# Altium schematic sheet WemosESP8266.SchDoc(WemosESP8266)
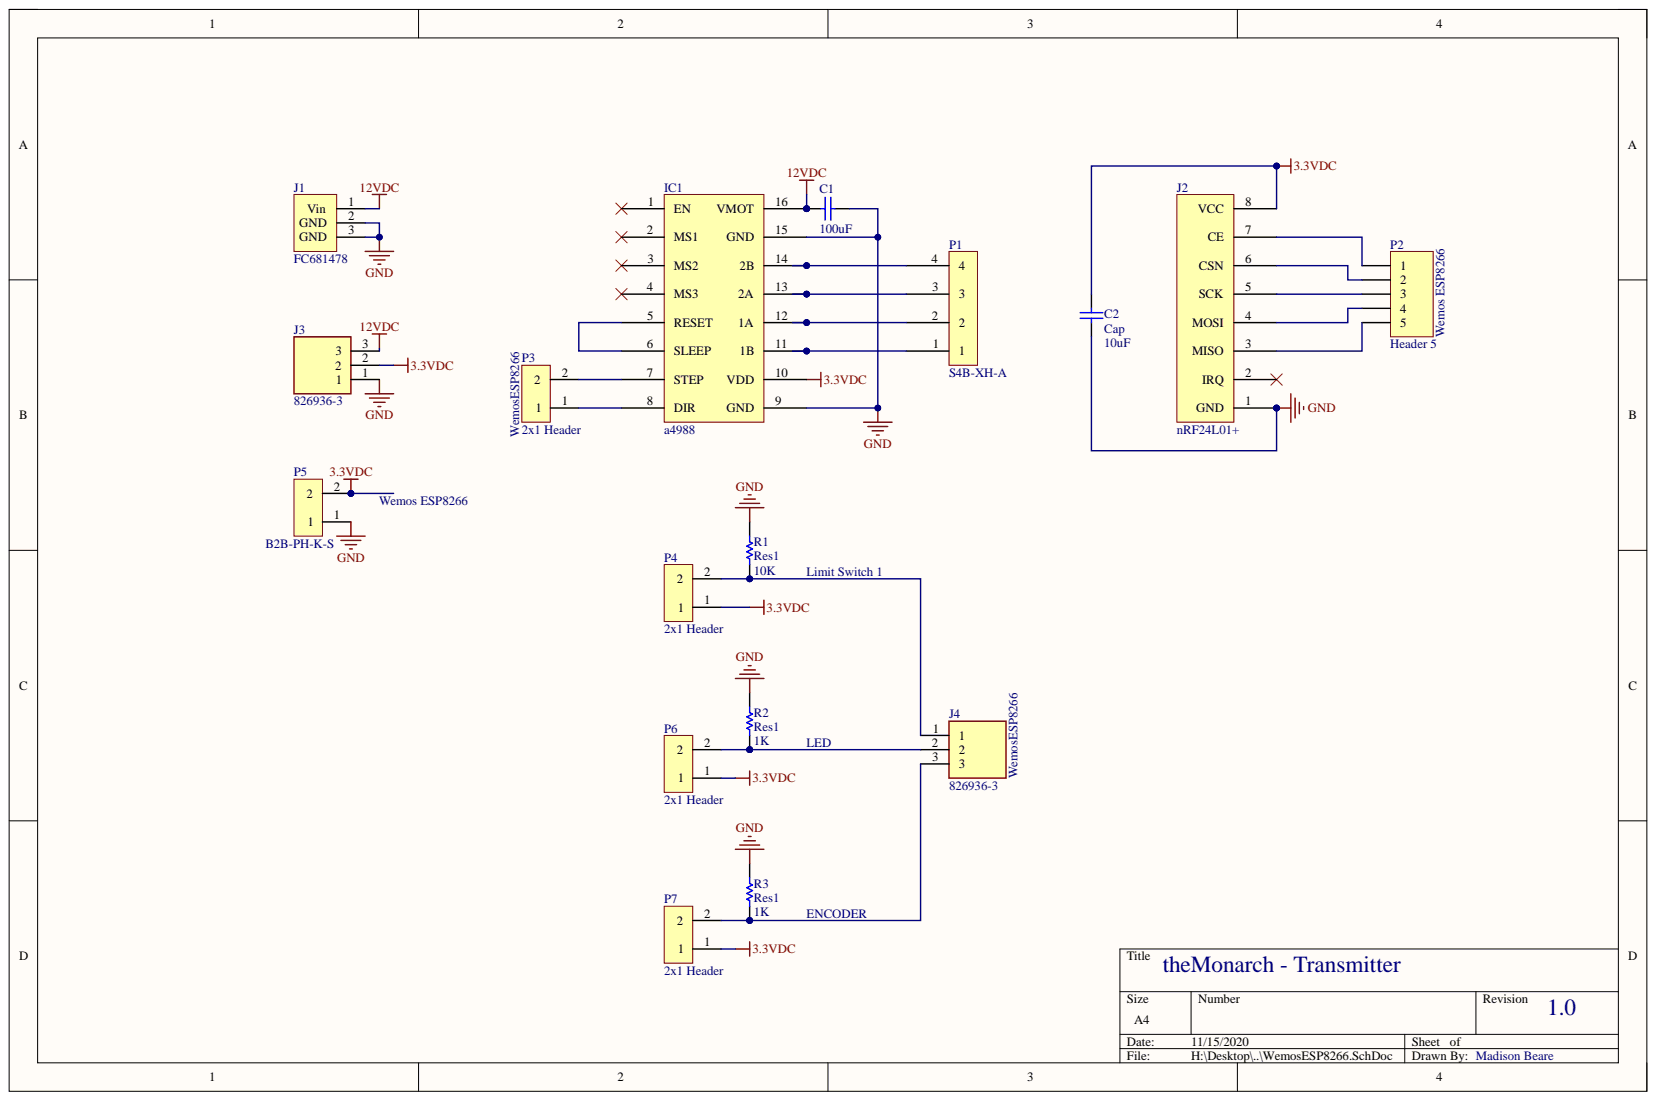
[diagram p0: WemosESP8266.SchDoc]

## Parts
C1  100uF  [RAD-0.3]
C2  Cap  value 10uF  [RAD-0.3]
IC1  a4988  [MODULE_A4988_STEPPER_MOTOR_DRIVER_CARRIER]
J1  FC681478  [FC681478]
J2  nRF24L01+  [TE_5-146278-8]
J3  826936-3  [TE_826936-3]
J4  826936-3  [TE_826936-3]
P1  S4B-XH-A  [S4B-XH-A(LF)(SN)]
P2  Header 5  [HDR1X5]
P3  2x1 Header  [JST_S2B-XH-A(LF)(SN)]
P4  2x1 Header  [JST_S2B-XH-A(LF)(SN)]
P5  B2B-PH-K-S  [JST_B2B-PH-K-S(LF)(SN)]
P6  2x1 Header  [JST_S2B-XH-A(LF)(SN)]
P7  2x1 Header  [JST_S2B-XH-A(LF)(SN)]
R1  Res1  value 10K  [AXIAL-0.3]
R2  Res1  value 1K  [AXIAL-0.3]
R3  Res1  value 1K  [AXIAL-0.3]

## Nets
3.3VDC: C2.2 IC1.10(VDD) J2.8(VCC) J3.2 P4.1 P5.2 P6.1 P7.1
12VDC: C1.1 IC1.16(VMOT) J1.1 J3.3
GND: C1.2 C2.1 IC1.9(GND) IC1.15(GND) J1.2 J1.3 J2.1(GND) J3.1 P5.1 R1.2 R2.2 R3.2
NetIC1_5: IC1.5(RESET) IC1.6(SLEEP)
NetIC1_7: IC1.7(STEP) P3.2
NetIC1_8: IC1.8(DIR) P3.1
NetIC1_11: IC1.11(1B) P1.1
NetIC1_12: IC1.12(1A) P1.2
NetIC1_13: IC1.13(2A) P1.3
NetIC1_14: IC1.14(2B) P1.4
NetJ2_3: J2.3(MISO) P2.5
NetJ2_4: J2.4(MOSI) P2.4
NetJ2_5: J2.5(SCK) P2.3
NetJ2_6: J2.6(CSN) P2.2
NetJ2_7: J2.7(CE) P2.1
NetJ4_1: J4.1 P4.2 R1.1
NetJ4_2: J4.2 P6.2 R2.1
NetJ4_3: J4.3 P7.2 R3.1

Not connected: IC1 1(EN) 2(MS1) 3(MS2) 4(MS3); J2 2(IRQ)

## Library data of the parts
C1 = Cap: Capacitor; Value: 100pF; Component Kind: Standard; LatestRevisionDate: 17-Jul-2002; LatestRevisionNote: Re-released for DXP Platform.; Library Name: Miscellaneous Devices.IntLib; PackageDescription: Radial Cap, Thru-Hole; 2 Leads; 0.3 in Pin Spacing; PackageReference: RAD-0.3; Published: 8-Jun-2000; Signal Integrity: Cap; Simulation: CAP; Publisher: Altium Limited
C2 = Cap: Capacitor; Component Kind: Standard; LatestRevisionDate: 17-Jul-2002; LatestRevisionNote: Re-released for DXP Platform.; Library Name: Miscellaneous Devices.IntLib; PackageDescription: Radial Cap, Thru-Hole; 2 Leads; 0.3 in Pin Spacing; PackageReference: RAD-0.3; Published: 8-Jun-2000; Signal Integrity: Cap; Simulation: CAP; Publisher: Altium Limited
J1 = FC681478: CLIFF ELECTRONIC COMPONENTS         FC681478            CONNECTOR, POWER ENTRY, RCPT, 2A, 16VDC; Gender: Male; Voltage Rating: 16V; Category: Connectors; Color: Black; Component Kind: Standard; ComponentLink1Description: https://datasheet.ciiva.com/46428/1859070-46428805.pdf?src-supplier=Element14; ComponentLink1URL: https://datasheet.ciiva.com/46428/1859070-46428805.pdf?src-supplier=Element14; Current Rating: 2A; Length: 15.2mm; Manufacturer: Cliff; Manufacturer Part Number: FC681478; Mount: Through Hole; Orientation: Right Angle; Pricing 1: 1=1.94000, 50=0.87800, 100=0.78800, 250=0.70300, 500=0.66600, 1000=0.65500 (GBP); REACH SVHC: No SVHC; RoHS: Yes; Stock 1: 534; Supplier 1: Farnell; Supplier Part Number 1: 2450496; Termination: Through Hole; Voltage Rating (DC): 16V; Width: 9mm; Library Name: WemosESP8266_SchLib.SchLib
J2 = WRL-00691: SPARKFUN TRANSCEIVER BREAKOUT -; Frequency: 2.4GHz; Category: RF Semiconductors and Devices; Component Kind: Standard; ComponentLink1Description: https://github.com/sparkfun/Transceiver_Breakout-nRF24L01/tree/V_1.3?src-supplier=Digi-Key; ComponentLink1URL: https://github.com/sparkfun/Transceiver_Breakout-nRF24L01/tree/V_1.3?src-supplier=Digi-Key; Manufacturer: SparkFun; Manufacturer Part Number: WRL-00691; Pricing 1: 1=20.95000 (USD); RoHS: No; Stock 1: 59; Supplier 1: Digi-Key; Supplier Part Number 1: 1568-1295-ND; Library Name: WemosESP8266_SchLib.SchLib
J3 J4 = 826936-3: Connector Header Through Hole 3 position 0.100 (2.54mm); MF: TE Connectivity; Availability: Unavailable; Component Kind: Standard; EU_RoHS_Compliance: Compliant; Library Name: 826936-3.IntLib; MP: 826936-3; Package: None; Price: None; SnapEDA_Link: https://www.snapeda.com/parts/826936-3/TE%20Connectivity%20AMP%20Connectors/view-part/2390122/?ref=snap
P1 = S4B-XH-A(LF)(SN): Conn Shrouded Header HDR 4 POS 2.5mm Solder RA Thru-Hole Box; Manufacturer: JST; Connector Type: Male Pin; Contact Resistance: 20mR; Current Rating: 3A; Gender: Male; Insulation Resistance: 1GR; Max Voltage Rating (AC): 250V; Number of Contacts: 4; Wire/Cable Gauge: 22AWG; Category: Headers and Wire Housings; Color: Natural; Component Kind: Standard; ComponentLink1Description: https://datasheet.ciiva.com/726/exh-726400.pdf?src-supplier=Digi-Key; ComponentLink1URL: https://datasheet.ciiva.com/726/exh-726400.pdf?src-supplier=Digi-Key; ComponentLink2Description: https://datasheet.ciiva.com/26924/getdatasheetpartid-449045-26924078.pdf?src-supplier=Verical; ComponentLink2URL: https://datasheet.ciiva.com/26924/getdatasheetpartid-449045-26924078.pdf?src-supplier=Verical; ComponentLink3Description: https://datasheet.ciiva.com/8052/5448-8052236.pdf?src-supplier=Element14; ComponentLink3URL: https://datasheet.ciiva.com/8052/5448-8052236.pdf?src-supplier=Element14; Contact Material: Brass; Contact Plating: Tin,Lead,Copper; Depth: 11.5mm; Housing Color: White; Housing Material: Polyamide; Lead Free: Lead Free; Lead Pitch: 2.5mm; Length: 12.4mm; Manufacturer Part Number: S4B-XH-A(LF)(SN); Max Current Rating: 3A; Max Operating Temperature: 85°C; Min Operating Temperature: -25°C; Mount: Through Hole; Number of Positions: 4; Number of Rows: 1; Orientation: Right Angle; Package Quantity: 500; Packaging: Bulk; Pitch: 2.5mm; Pricing 1: 1=0.27000, 10=0.25700, 100=0.17850, 500=0.15100, 1000=0.12355, 5000=0.10982, 10000=0.09953 (USD); REACH SVHC: No SVHC; RoHS: Yes; Stock 1: 8950; Supplier 1: Digi-Key; Supplier Part Number 1: 455-2243-ND; Termination: Press-Fit; Voltage Rating: 250V; Voltage Rating (AC): 250V; Library Name: WemosESP8266_SchLib.SchLib
P2 = Header 5: Header, 5-Pin; Component Kind: Standard; LatestRevisionDate: 17-Jul-2002; LatestRevisionNote: Re-released for DXP Platform.; Library Name: Miscellaneous Connectors.IntLib; Signal Integrity: Connector; Publisher: Altium Limited
P3 P4 P6 P7 = S2B-XH-A(LF)(SN): Conn Shrouded Header HDR 2 POS 2.5mm Solder RA Thru-Hole Box; Manufacturer: JST; Connector Type: Male Pin; Contact Resistance: 20mR; Current Rating: 3A; Gender: Male; Insulation Resistance: 1GR; Max Voltage Rating (AC): 250V; Number of Contacts: 2; Wire/Cable Gauge: 22AWG; Category: Headers and Wire Housings; Color: Natural; Component Kind: Standard; ComponentLink1Description: https://datasheet.ciiva.com/16208/s2b-xh-alfsn-16208978.pdf?src-supplier=Future+Electronics; ComponentLink1URL: https://datasheet.ciiva.com/16208/s2b-xh-alfsn-16208978.pdf?src-supplier=Future+Electronics; ComponentLink2Description: https://datasheet.ciiva.com/8052/1684882-8052211.pdf?src-supplier=Element14; ComponentLink2URL: https://datasheet.ciiva.com/8052/1684882-8052211.pdf?src-supplier=Element14; ComponentLink3Description: https://datasheet.ciiva.com/11746/0900766b8082f560-11746947.pdf?src-supplier=RSComponents; ComponentLink3URL: https://datasheet.ciiva.com/11746/0900766b8082f560-11746947.pdf?src-supplier=RSComponents; ComponentLink4Description: https://datasheet.ciiva.com/26924/getdatasheetpartid-645395-26924076.pdf?src-supplier=Verical; ComponentLink4URL: https://datasheet.ciiva.com/26924/getdatasheetpartid-645395-26924076.pdf?src-supplier=Verical; ComponentLink5Description: https://datasheet.ciiva.com/26894/getdatasheetpartid-640270-26894603.pdf?src-supplier=Verical; ComponentLink5URL: https://datasheet.ciiva.com/26894/getdatasheetpartid-640270-26894603.pdf?src-supplier=Verical; ComponentLink6Description: https://datasheet.ciiva.com/26894/getdatasheetpartid-441330-26894909.pdf?src-supplier=Verical; ComponentLink6URL: https://datasheet.ciiva.com/26894/getdatasheetpartid-441330-26894909.pdf?src-supplier=Verical; ComponentLink7Description: https://datasheet.ciiva.com/20207/523653-20207183.pdf?src-supplier=Rapid+Electronics; ComponentLink7URL: https://datasheet.ciiva.com/20207/523653-20207183.pdf?src-supplier=Rapid+Electronics; ComponentLink8Description: https://datasheet.ciiva.com/726/exh-726412.pdf?src-supplier=Digi-Key; ComponentLink8URL: https://datasheet.ciiva.com/726/exh-726412.pdf?src-supplier=Digi-Key; Contact Material: Brass; Contact Plating: Tin,Copper,Lead; Depth: 11.5mm; Housing Color: White; Housing Material: Polyamide; Lead Free: Lead Free; Lead Pitch: 2.5mm; Length: 7.4mm; Manufacturer Part Number: S2B-XH-A(LF)(SN); Max Current Rating: 3A; Max Operating Temperature: 85°C; Max Voltage Rating (DC): 250V; Min Operating Temperature: -25°C; Mount: Through Hole; Number of Positions: 2; Number of Rows: 1; Orientation: Right Angle; Package Quantity: 1000; Packaging: Bulk; Pitch: 2.5mm; Pricing 1: 1=0.18000, 10=0.16800, 100=0.11710, 500=0.09904, 1000=0.08104, 5000=0.07204, 10000=0.06528 (USD); REACH SVHC: No SVHC; RoHS: Yes; Stock 1: 54562; Supplier 1: Digi-Key; Supplier Part Number 1: 455-2257-ND; Termination: Press-Fit; Voltage Rating: 250V; Voltage Rating (AC): 250V; Library Name: WemosESP8266_SchLib.SchLib
P5 = B2B-PH-K-S(LF)(SN): Connector Header, PH Series, 2 Position, 2.00mm, Through Hole, Press-Fit, Solder, Natural; Manufacturer: JST; Category: Connectors; Component Kind: Standard; ComponentLink1Description: http://www.farnell.com/datasheets/2057211.pdf?src-supplier=Farnell; ComponentLink1URL: http://www.farnell.com/datasheets/2057211.pdf?src-supplier=Farnell; ComponentLink2Description: http://www.farnell.com/datasheets/2057211.pdf?src-supplier=Newark; ComponentLink2URL: http://www.farnell.com/datasheets/2057211.pdf?src-supplier=Newark; ComponentLink3Description: http://www.farnell.com/datasheets/2057211.pdf?src-supplier=Element14; ComponentLink3URL: http://www.farnell.com/datasheets/2057211.pdf?src-supplier=Element14; Height: 0.35inch; Length: 0.2inch; Manufacturer Part Number: B2B-PH-K-S(LF)(SN); Pricing 1: 1=0.17000, 10=0.16200, 100=0.10020, 500=0.08288, 1000=0.07252, 5000=0.06216, 10000=0.06044 (USD); RoHS: Yes; Stock 1: 37001; Supplier 1: Digi-Key; Supplier Part Number 1: 455-1704-ND; Weight: 0.0625lb; Width: 0.24inch; Library Name: WemosESP8266_SchLib.SchLib
R1-R3 = Res1: Resistor; Component Kind: Standard; LatestRevisionDate: 17-Jul-2002; LatestRevisionNote: Re-released for DXP Platform.; Library Name: Miscellaneous Devices.IntLib; PackageDescription: Axial Device, Thru-Hole; 2 Leads; 0.3 in Pin Spacing; PackageReference: AXIAL-0.3; Published: 8-Jun-2000; Signal Integrity: Res; Simulation: RESISTOR; Publisher: Altium Limited
IC1 = a4988: Component Kind: Standard; ComponentLink1Description: New link; Library Name: WemosESP8266_SchLib.SchLib
